# Altium schematic sheet Sheet5.SchDoc
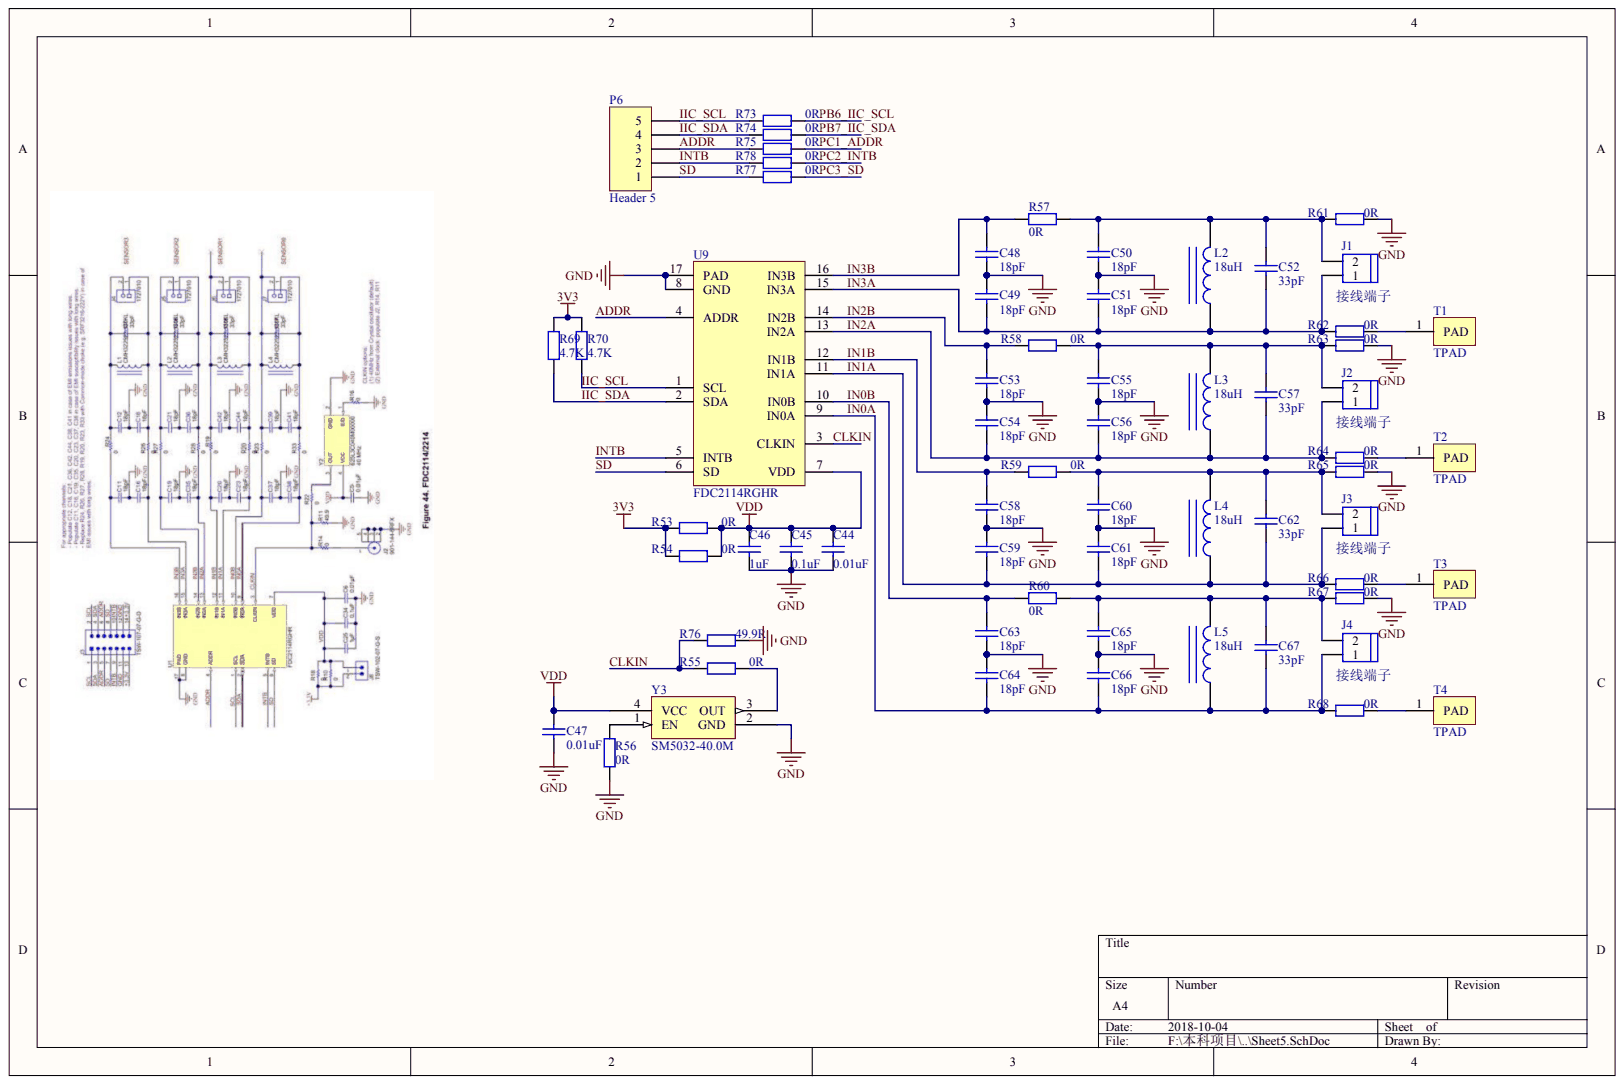
[diagram p0: Sheet5.SchDoc]

## Parts
C44  0.01uF
C45  0.1uF
C46  1uF
C47  0.01uF
C48  18pF
C49  18pF
C50  18pF
C51  18pF
C52  33pF
C53  18pF
C54  18pF
C55  18pF
C56  18pF
C57  33pF
C58  18pF
C59  18pF
C60  18pF
C61  18pF
C62  33pF
C63  18pF
C64  18pF
C65  18pF
C66  18pF
C67  33pF
J1  接线端子
J2  接线端子
J3  接线端子
J4  接线端子
L2  18uH
L3  18uH
L4  18uH
L5  18uH
P6  Header 5
R53  0R
R54  0R
R55  0R
R56  0R
R57  0R
R58  0R
R59  0R
R60  0R
R61  0R
R62  0R
R63  0R
R64  0R
R65  0R
R66  0R
R67  0R
R68  0R
R69  4.7K
R70  4.7K
R73  0R
R74  0R
R75  0R
R76  49.9R
R77  0R
R78  0R
T1  TPAD
T2  TPAD
T3  TPAD
T4  TPAD
U9  FDC2114RGHR
Y3  SM5032-40.0M

## Nets
3V3: R53.1 R54.1 R69.2 R70.2
GND: C44.1 C45.1 C46.1 C47.1 C48.1 C49.2 C50.1 C51.2 C53.1 C54.2 C55.1 C56.2 C58.1 C59.2 C60.1 C61.2 C63.1 C64.2 C65.1 C66.2 R56.1 R61.2 R63.2 R65.2 R67.2 R76.2 U9.8(GND) U9.17(PAD) Y3.2(GND)
VDD: C44.2 C45.2 C46.2 C47.2 R53.2 R54.2 U9.7(VDD) Y3.4(VCC)
ADDR: P6.3 R75.1 U9.4(ADDR)
CLKIN: R55.1 R76.1 U9.3(CLKIN)
IIC_SCL: P6.5 R70.1 R73.1 U9.1(SCL)
IIC_SDA: P6.4 R69.1 R74.1 U9.2(SDA)
IN0A: C64.1 C66.1 C67.1 J4.1 L5.1 R68.1 U9.9(IN0A)
IN0B: C63.2 R60.1 U9.10(IN0B)
IN1A: C59.1 C61.1 C62.1 J3.1 L4.1 R66.1 U9.11(IN1A)
IN1B: C58.2 R59.1 U9.12(IN1B)
IN2A: C54.1 C56.1 C57.1 J2.1 L3.1 R64.1 U9.13(IN2A)
IN2B: C53.2 R58.1 U9.14(IN2B)
IN3A: C49.1 C51.1 C52.1 J1.1 L2.1 R62.1 U9.15(IN3A)
IN3B: C48.2 R57.1 U9.16(IN3B)
INTB: P6.2 R78.1 U9.5(INTB)
PB6_IIC_SCL: R73.2
PB7_IIC_SDA: R74.2
PC1_ADDR: R75.2
PC2_INTB: R78.2
PC3_SD: R77.2
SD: P6.1 R77.1 U9.6(SD)
NetC50_2: C50.2 C52.2 J1.2 L2.2 R57.2 R61.1
NetC55_2: C55.2 C57.2 J2.2 L3.2 R58.2 R63.1
NetC60_2: C60.2 C62.2 J3.2 L4.2 R59.2 R65.1
NetC65_2: C65.2 C67.2 J4.2 L5.2 R60.2 R67.1
NetR55_2: R55.2 Y3.3(OUT)
NetR56_2: R56.2 Y3.1(EN)
NetR62_2: R62.2 T1.1
NetR64_2: R64.2 T2.1
NetR66_2: R66.2 T3.1
NetR68_2: R68.2 T4.1

## Library data of the parts
C44-C67 J1-J4 L2-L5 P6 R53-R70 R73-R78 T1-T4 U9 Y3 = (no symbol name)
  C44-C67: ComponentLink1Description: 供应商链接; ComponentLink1URL: http://www.szlcsc.com/product/details_16531.html; Package: 0603; Supplier: LC; SuppliersPartNumber: C15849
  L2-L5: ComponentLink1URL: http://www.szlcsc.com/product/details_14964.html; Package: 0805; Supplier: LC; SuppliersPartNumber: C14304
  P6: LatestRevisionDate: 17-Jul-2002; LatestRevisionNote: Re-released for DXP Platform.; Publisher: Altium Limited
  R53-R70 R73-R78: ComponentLink1Description: 供应商链接; ComponentLink1URL: http://www.szlcsc.com/product/details_23570.html; Package: 0603; Supplier: LC; SuppliersPartNumber: C22843
